# Altium schematic sheet p1_bt_sorry.SchDoc(p1_bt_sorry) (continued)
## Library data of the parts (continued)
U1 = ECMF02-4CMX8: COMMON MODE CHOKE 2LN SMD ESD; Manufacturer: STMicroelectronics; Number of Lines: 2; DC Resistance (DCR): 4R; Width: 0.047inch; Termination: Flat; Supplier Part Number 1: 497-13420-1-ND; Supplier 1: Digi-Key; Stock 1: 15783; REACH SVHC: No SVHC; Pricing 1: 1=0.92, 10=0.826, 100=0.6444, 500=0.5323, 1000=0.42024 (USD); Packaging: Tape and Reel; Package Quantity: 3000; Number of Pins: 8; Mount: Surface Mount; Min Operating Temperature: -30°C; Max Operating Temperature: 85°C; Manufacturer Part Number: ECMF02-4CMX8; Library Name: ICs.SchLib; Length: 0.098inch; Lead Free: Lead Free; Height: 0.022inch; Depth: 1.25mm; ComponentLink4URL: https://datasheet.ciiva.com/6215/dm00039389-6215581.pdf; ComponentLink4Description: https://datasheet.ciiva.com/6215/dm00039389-6215581.pdf; ComponentLink3URL: https://datasheet.ciiva.com/12071/dm00039389-211609-12071466.pdf; ComponentLink3Description: https://datasheet.ciiva.com/12071/dm00039389-211609-12071466.pdf; ComponentLink2URL: https://datasheet.ciiva.com/6215/dm00039389-6215578.pdf; ComponentLink2Description: https://datasheet.ciiva.com/6215/dm00039389-6215578.pdf; ComponentLink1URL: https://datasheet.ciiva.com/6215/dm00039389-6215574.pdf; ComponentLink1Description: https://datasheet.ciiva.com/6215/dm00039389-6215574.pdf; Component Kind: Standard; Case/Package: QFN
U2 = MDBT42Q: MDBT42Q NRF52832 BASED BLE MODUL; Manufacturer Part Number: 317030213; Manufacturer: Seeed Studio; Supplier Part Number 1: 1597-1434-ND; Supplier 1: Digi-Key; Stock 1: 333; RoHS: No; Pricing 1: 1 = 10.10000 (USD); Library Name: ICs.SchLib; ComponentLink1URL: http://statics3.seeedstudio.com/assets/file/bazaar/product/MDBT42Q-Version_B.pdf?src-supplier=Digi-Key; ComponentLink1Description: http://statics3.seeedstudio.com/assets/file/bazaar/product/MDBT42Q-Version_B.pdf?src-supplier=Digi-Key; Component Kind: Standard
U3 = MCP73831T-2ATI/OT: IC CONTROLLR LI-ION 4.2V SOT23-5; Manufacturer: Microchip; Output Current: 500mA; Number of Cells: 1; Min Supply Voltage: 3.75V; Min Output Voltage: 4.168V; Max Supply Voltage: 6V; Max Output Voltage: 4.232V; Width: 1.8mm; Supplier Part Number 1: MCP73831T-2ATI/OTCT-ND; Supplier 1: Digi-Key; Stock 1: 22234; RoHS: Yes; REACH SVHC: No SVHC; Pricing 1: 1 = 0.58000, 25 = 0.48400, 100 = 0.43260 (USD); Packaging: Tape and Reel; Package Quantity: 3000; Output Voltage: 4.2V; Number of Pins: 5; Mount: Surface Mount; Min Operating Temperature: -40°C; Max Output Current: 500mA; Max Operating Temperature: 85°C; Max Charge Current: 500mA; Manufacturer Part Number: MCP73831T-2ATI/OT; Library Name: ICs.SchLib; Length: 3.1mm; Lead Free: Lead Free; Height: 1.3mm; ComponentLink9URL: https://datasheet.ciiva.com/3213/21984e-3213405.pdf?src-supplier=Avnet; ComponentLink9Description: https://datasheet.ciiva.com/3213/21984e-3213405.pdf?src-supplier=Avnet; ComponentLink8URL: https://datasheet.ciiva.com/16217/filehandler-aspxddocname-en025112-16217052.pdf?src-supplier=Future+Electronics; ComponentLink8Description: https://datasheet.ciiva.com/16217/filehandler-aspxddocname-en025112-16217052.pdf?src-supplier=Future+Electronics; ComponentLink7URL: https://datasheet.ciiva.com/8039/630369-8039388.pdf?src-supplier=Element14; ComponentLink7Description: https://datasheet.ciiva.com/8039/630369-8039388.pdf?src-supplier=Element14; ComponentLink6URL: https://datasheet.ciiva.com/1137/630369-1137804.pdf?src-supplier=Element14; ComponentLink6Description: https://datasheet.ciiva.com/1137/630369-1137804.pdf?src-supplier=Element14; ComponentLink5URL: https://datasheet.ciiva.com/17299/21984e-17299304.pdf?src-supplier=Avnet+Europe; ComponentLink5Description: https://datasheet.ciiva.com/17299/21984e-17299304.pdf?src-supplier=Avnet+Europe; ComponentLink4URL: https://datasheet.ciiva.com/1931/21984e-1931958.pdf?src-supplier=Digi-Key; ComponentLink4Description: https://datasheet.ciiva.com/1931/21984e-1931958.pdf?src-supplier=Digi-Key; ComponentLink3URL: https://datasheet.ciiva.com/1931/21984e-1931957.pdf?src-supplier=Digi-Key; ComponentLink3Description: https://datasheet.ciiva.com/1931/21984e-1931957.pdf?src-supplier=Digi-Key; ComponentLink2URL: https://datasheet.ciiva.com/1931/21984e-1931956.pdf?src-supplier=Digi-Key; ComponentLink2Description: https://datasheet.ciiva.com/1931/21984e-1931956.pdf?src-supplier=Digi-Key; ComponentLink1URL: https://datasheet.ciiva.com/26344/651522-da-01-en-contr-mcp73831t-2ati-ot-sot-23-5-mcp-26344457.pdf?src-supplier=Conrad; ComponentLink1Description: https://datasheet.ciiva.com/26344/651522-da-01-en-contr-mcp73831t-2ati-ot-sot-23-5-mcp-26344457.pdf?src-supplier=Conrad; Component Kind: Standard; Case/Package: SOT-23
U4 = CP2104-F03-GMR: IC SGL USB-TO-UART BRIDGE 24QFN; Manufacturer: Silicon Labs; Operating Supply Voltage: 3.3V; Operating Supply Current: 17mA; Number of I/Os: 4; Number of Channels: 1; Min Supply Voltage: 3V; Max Supply Voltage: 3.6V; Interface: UART; Data Rate: 2Mbps; Weight: 0.001764oz; Supplier Part Number 1: 336-4146-1-ND; Supplier 1: Digi-Key; Stock 1: 20484; RoHS: Yes; Radiation Hardening: No; Pricing 1: 1 = 1.65000, 25 = 1.58640, 100 = 1.58360 (USD); Packaging: Tape and Reel; Package Quantity: 1500; Number of Pins: 24; Mount: Surface Mount; Min Operating Temperature: -40°C; Max Operating Temperature: 85°C; Manufacturer Part Number: CP2104-F03-GMR; Library Name: ICs.SchLib; Contact Plating: Tin; ComponentLink3URL: https://datasheet.ciiva.com/15150/getdatasheetpartid-711722-15150533.pdf?src-supplier=Verical; ComponentLink3Description: https://datasheet.ciiva.com/15150/getdatasheetpartid-711722-15150533.pdf?src-supplier=Verical; ComponentLink2URL: https://datasheet.ciiva.com/16639/cp2104-16639756.pdf?src-supplier=Avnet+Europe; ComponentLink2Description: https://datasheet.ciiva.com/16639/cp2104-16639756.pdf?src-supplier=Avnet+Europe; ComponentLink1URL: https://datasheet.ciiva.com/14939/cp2104-14939979.pdf?src-supplier=Digi-Key; ComponentLink1Description: https://datasheet.ciiva.com/14939/cp2104-14939979.pdf?src-supplier=Digi-Key; Component Kind: Standard; Case/Package: QFN EP
U5 = TPS7A9001DSKR: ULTRA LOW NOISE 0.5A LDO WITH P; Manufacturer: Texas Instruments; Supplier Part Number 1: 296-48316-1-ND; Supplier 1: Digi-Key; Stock 1: 105; Pricing 1: 1=1.6, 10=1.441, 100=1.1584, 500=0.95172, 1000=0.78857 (USD); Min Operating Temperature: -40°C; Max Operating Temperature: 125°C; Manufacturer Part Number: TPS7A9001DSKR; Library Name: ICs.SchLib; Component Kind: Standard
